# Altium schematic sheet [3] - MC60 MODULE.SchDoc
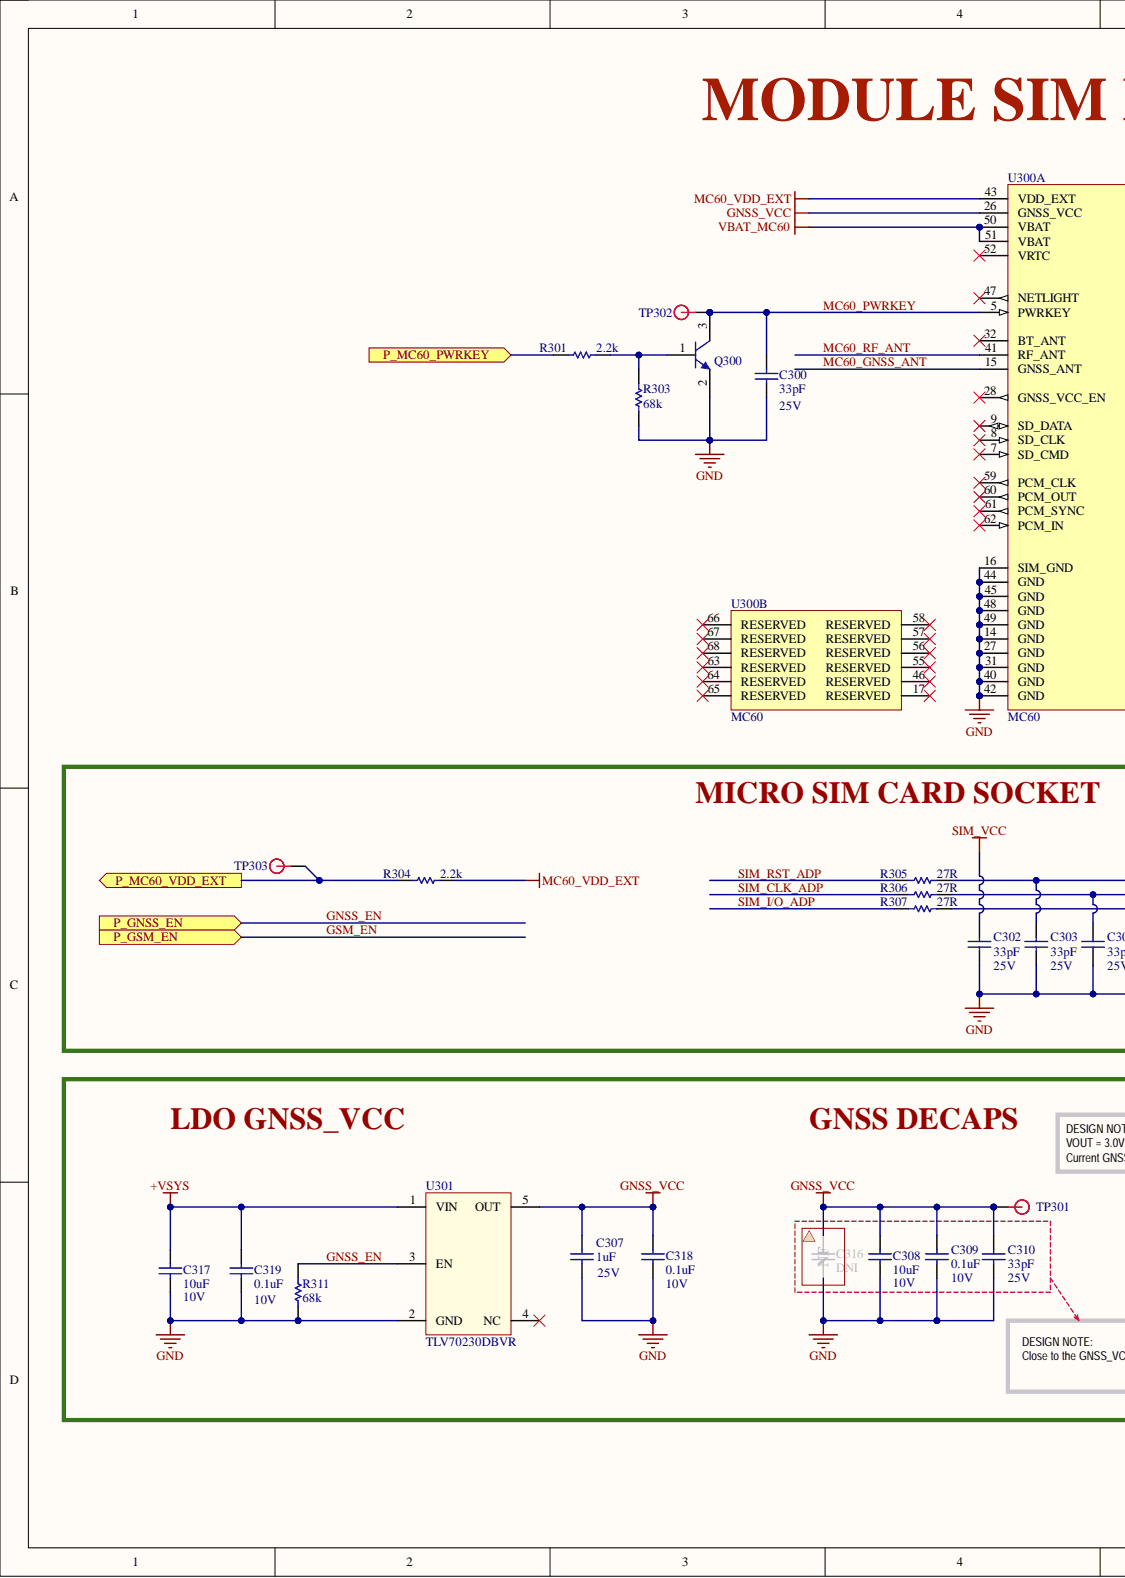
[diagram p0: [3] - MC60 MODULE.SchDoc, left]
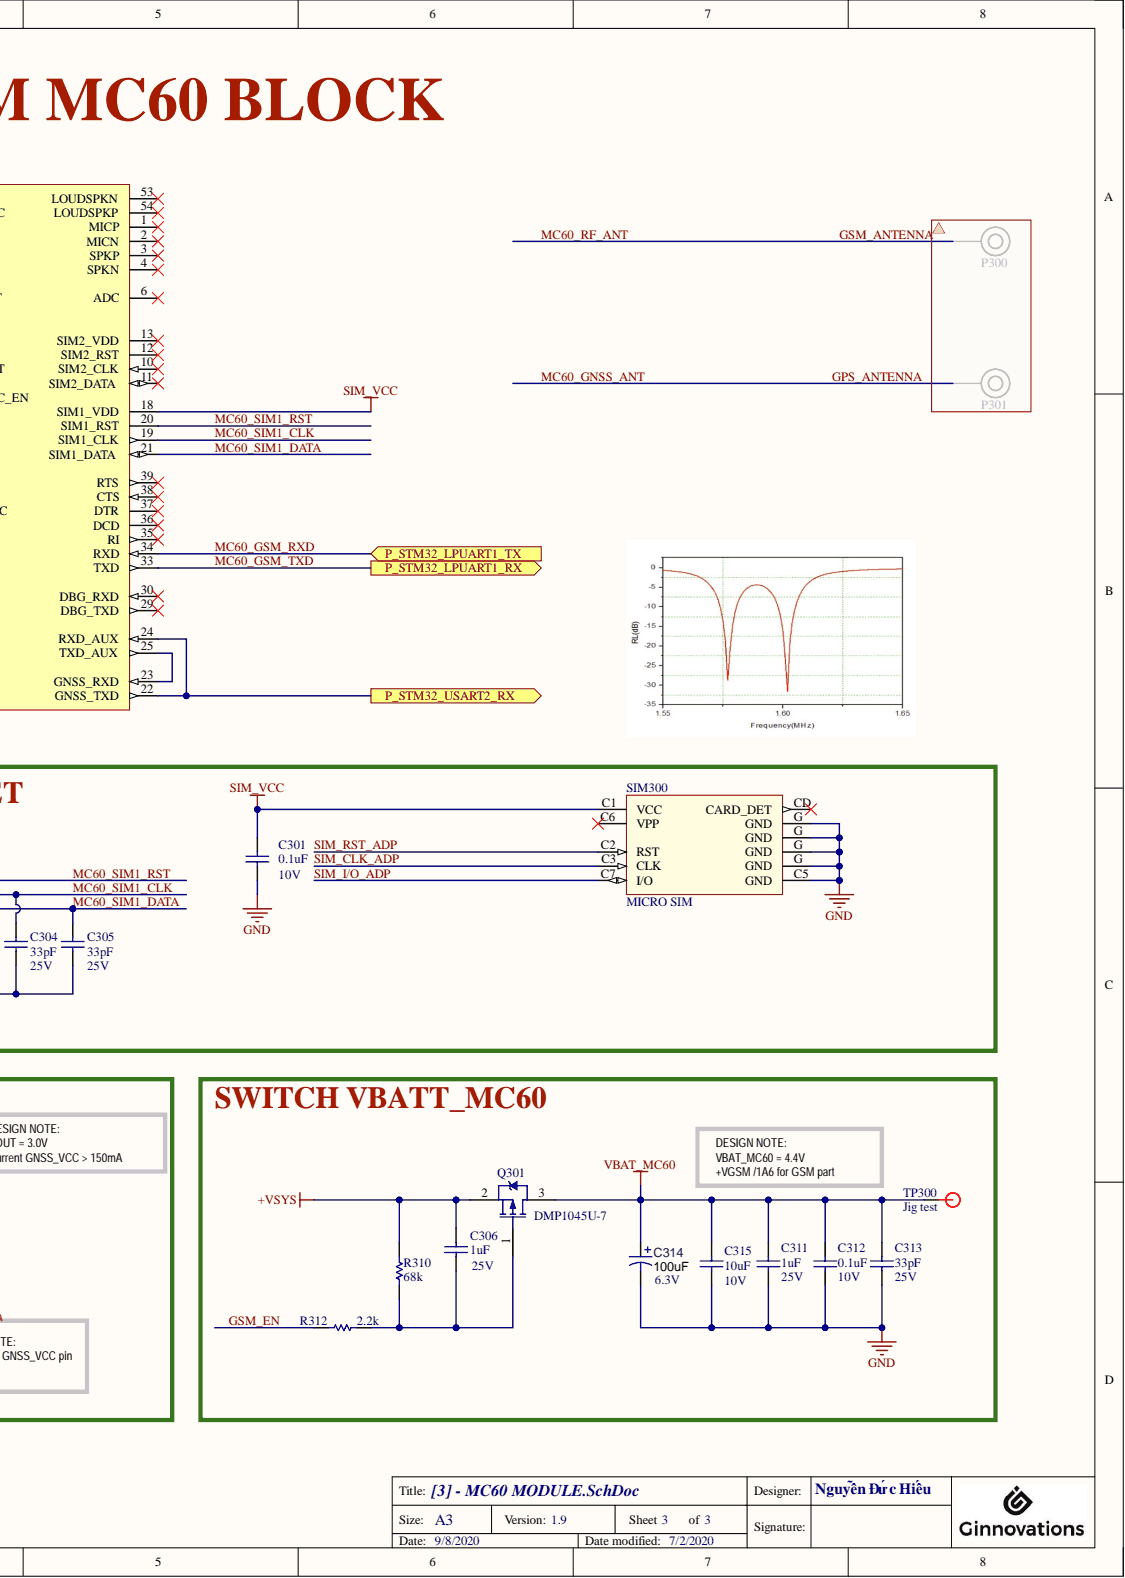
[diagram p1: [3] - MC60 MODULE.SchDoc, right]

## Parts
C300  33pF  Value2: 25V  [C0402L]
C301  0.1uF  Value2: 10V  [C0402L]
C302  33pF  Value2: 25V  [C0402L]
C303  33pF  Value2: 25V  [C0402L]
C304  33pF  Value2: 25V  [C0402L]
C305  33pF  Value2: 25V  [C0402L]
C306  1uF  Value2: 25V  [C0402L]
C307  1uF  Value2: 25V  [C0402L]
C308  10uF  Value2: 10V  [C0603Z]
C309  0.1uF  Value2: 10V  [C0402L]
C310  33pF  Value2: 25V  [C0402L]
C311  1uF  Value2: 25V  [C0402L]
C312  0.1uF  Value2: 10V  [C0402L]
C313  33pF  Value2: 25V  [C0402L]
C314  100uF  Value2: 6.3V  [6032-28]
C315  10uF  Value2: 10V  [C0603Z]
C317  10uF  Value2: 10V  [C0603Z]
C318  0.1uF  Value2: 10V  [C0402L]
C319  0.1uF  Value2: 10V  [C0402L]
Q300  MMBT3904  [SOT-23L]  (value not drawn)
Q301  DMP1045U-7  [SOT-23L]
R301  2.2k  [R0402L]
R303  68k  [R0402L]
R304  2.2k  [R0402L]
R305  27R  [R0402L]
R306  27R  [R0402L]
R307  27R  [R0402L]
R310  68k  [R0402L]
R311  68k  [R0402L]
R312  2.2k  [R0402L]
SIM300  MICRO SIM  [SI62C-01200]
TP300  Jig test  [TESTPOINT 1.2mm]  (no BOM)
TP301  Jig test  [TESTPOINT 1.2mm]  (value not drawn)  (no BOM)
TP302  2.54  [TESTPOINT 1.2mm]  (value not drawn)  (no BOM)
TP303  2.54  [TESTPOINT 1.2mm]  (value not drawn)  (no BOM)
U300  MC60  [MC60]  (parts A,B)
U301  TLV70230DBVR  [SOT23-5Z]

## Ports
P_GNSS_EN, P_GSM_EN, P_MC60_PWRKEY, P_MC60_VDD_EXT, P_STM32_LPUART1_RX, P_STM32_LPUART1_TX, P_STM32_USART2_RX

## Nets
+VSYS: C306.2 C317.1 C319.1 Q301.2 R310.1 U301.1(VIN)
GND: C300.2 C301.2 C302.2 C303.2 C304.2 C305.2 C307.1 C308.2 C309.2 C310.2 C311.2 C312.2 C313.2 C314.2 C315.2 C317.2 C318.2 C319.2 Q300.2 R303.1 R311.2 SIM300.C5(GND) SIM300.G(GND) U300.14(GND) U300.16(SIM_GND) U300.27(GND) U300.31(GND) U300.40(GND) U300.42(GND) U300.44(GND) U300.45(GND) U300.48(GND) U300.49(GND) U301.2(GND)
GNSS_VCC: C307.2 C308.1 C309.1 C310.1 C318.1 TP301.1 U300.26(GNSS_VCC) U301.5(OUT)
MC60_VDD_EXT: R304.1 U300.43(VDD_EXT)
SIM_VCC: C301.1 C302.1 SIM300.C1(VCC) U300.18(SIM1_VDD)
VBAT_MC60: C311.1 C312.1 C313.1 C314.1 C315.1 Q301.3 TP300.1 U300.50(VBAT) U300.51(VBAT)
GNSS_EN: R311.1 U301.3(EN) ; port P_GNSS_EN
GPS_ANTENNA: U300.15(GNSS_ANT)
GSM_ANTENNA: U300.41(RF_ANT)
GSM_EN: R312.1 ; port P_GSM_EN
MC60_GSM_RXD: U300.34(RXD) ; port P_STM32_LPUART1_TX
MC60_GSM_TXD: U300.33(TXD) ; port P_STM32_LPUART1_RX
MC60_PWRKEY: C300.1 Q300.3 TP302.1 U300.5(PWRKEY)
MC60_SIM1_CLK: C304.1 R306.2 U300.19(SIM1_CLK)
MC60_SIM1_DATA: C305.1 R307.2 U300.21(SIM1_DATA)
MC60_SIM1_RST: C303.1 R305.2 U300.20(SIM1_RST)
P_MC60_PWRKEY: R301.2 ; port P_MC60_PWRKEY
P_MC60_VDD_EXT: R304.2 TP303.1 ; port P_MC60_VDD_EXT
P_STM32_USART2_RX: U300.22(GNSS_TXD) U300.24(RXD_AUX) ; port P_STM32_USART2_RX
SIM_CLK_ADP: R306.1 SIM300.C3(CLK)
SIM_I/O_ADP: R307.1 SIM300.C7(I/O)
SIM_RST_ADP: R305.1 SIM300.C2(RST)
NetC306_1: C306.1 Q301.1 R310.2 R312.2
NetQ300_1: Q300.1 R301.1 R303.2
NetU300_23: U300.23(GNSS_RXD) U300.25(TXD_AUX)

Not connected: SIM300 C6(VPP) CD(CARD_DET); U300 1(MICP) 2(MICN) 3(SPKP) 4(SPKN) 6(ADC) 7(SD_CMD) 8(SD_CLK) 9(SD_DATA) 10(SIM2_CLK) 11(SIM2_DATA) 12(SIM2_RST) 13(SIM2_VDD) 17(RESERVED) 28(GNSS_VCC_EN) 29(DBG_TXD) 30(DBG_RXD) 32(BT_ANT) 35(RI) 36(DCD) 37(DTR) 38(CTS) 39(RTS) 46(RESERVED) 47(NETLIGHT) 52(VRTC) 53(LOUDSPKN) 54(LOUDSPKP) 55(RESERVED) 56(RESERVED) 57(RESERVED) 58(RESERVED) 59(PCM_CLK) 60(PCM_OUT) 61(PCM_SYNC) 62(PCM_IN) 63(RESERVED) 64(RESERVED) 65(RESERVED) 66(RESERVED) 67(RESERVED) 68(RESERVED); U301 4(NC)

## Library data of the parts
C300 C302-C305 C310 C313 = C0402: CAP CER 0402; Manufacturer: Murata Electronics; Manufacturer Part Number: GRM1555C1E330JA01D; Code HW: EAPCA00028; Comment Description: CAP CER 33PF 25V C0G/NP0 0402; Tolerance: 20%; Types: Capacitor; Case / Package: 0402; Component Kind: Standard; Ginno Items Number Stock: N/A; Ginno Part Number: N/A; Mounting Type: Surface Mount; Price: N/A; Value: 33pF
  C300 C302-C305: Library Name: Ginno.SCHLIB
  C310 C313: Library Name: Xphone Rev2.4.SCHLIB
C301 C309 C312 C318 C319 = C0402: CAP CER 0402; Manufacturer: Murata Electronics; Manufacturer Part Number: GRM155R71A104KA01D; Code HW: EAPCA00006; Comment Description: Multilayer Ceramic Capacitors MLCC - SMD/SMT 0402 0.1uF 10volts X7R 10%; Tolerance: 10%; Types: Capacitor; Case / Package: 0402; Component Kind: Standard; Ginno Items Number Stock: N/A; Ginno Part Number: N/A; Mounting Type: Surface Mount; Price: N/A; Value: 0.1uF
  C301: Library Name: Ginno Passive.SchLib
  C309 C312 C318 C319: Library Name: Xphone Rev2.4.SCHLIB
C306 C307 C311 = C0402: CAP CER 0402; Manufacturer: TDK Corporation; Manufacturer Part Number: C1005X5R1E105M050BC; Code HW: EAPCA00021; Comment Description: Multilayer Ceramic Capacitors MLCC - SMD/SMT 0402 25V 1uF X5R 20% T: 0.5mm; Tolerance: 20%; Types: Capacitor; Case / Package: 0402; Component Kind: Standard; Ginno Items Number Stock: N/A; Ginno Part Number: N/A; Mounting Type: Surface Mount; Price: N/A; Value: 1uF
  C306 C307: Library Name: Ginno Passive.SchLib
  C311: Library Name: Xphone Rev2.4.SCHLIB
C308 C315 C317 = C0603: CAP CER 0603; Manufacturer: Taiyo Yuden; Manufacturer Part Number: LMK107BBJ106MALT; Code HW: EAPCA00025; Comment Description: CAP CER 10UF 10V X5R 0603; Tolerance: 20%; Types: Capacitor; Case / Package: 0603; Component Kind: Standard; Ginno Items Number Stock: N/A; Ginno Part Number: N/A; Mounting Type: Surface Mount; Price: N/A; Value: 10uF
  C308 C317: Library Name: Xphone Rev2.4.SCHLIB
  C315: Library Name: Ginno.SCHLIB
C314 = CAP POL: CAP TANT 100UF 10% 10V 6032; Manufacturer: AVX Corporation; Manufacturer Part Number: TAJC107M006RNJ; Code HW: EAPCA00029; Comment Description: CAP TANT 100UF 20% 6.3V 2312; Tolerance: 20%; Types: Capacitor; Case / Package: 6032; Component Kind: Standard; Ginno Items Number Stock: N/A; Ginno Part Number: N/A; Mounting Type: Surface Mount; Price: N/A; Signal Integrity: Cap; Simulation: Cap; Value: 100uF; Library Name: Ginno.SCHLIB
Q300 = MMBT3904: Bipolar Transistors - BJT BIPOLAR TRANS NPN; Manufacturer: Diodes Incorporated; Manufacturer Part Number: MMBT3904-7-F; Code HW: EAPTR00001; Comment Description: TRANS NPN 40V 0.2A SMD SOT23-3; Value: MMBT3904-7-F; Case / Package: N/A; Component Kind: Standard; Ginno Items Number Stock: N/A; Ginno Part Number: N/A; Mounting Type: Surface Mount; Price: N/A; Tolerance: N/A; Types: Transistor; Value2: N/A; Library Name: Ginno.SCHLIB
Q301 = SIA449DJ: MOSFET P-CH 12V 4A SOT23; Manufacturer: Diodes Incorporated; Code HW: EAPTR00002; Types: Transistor; Case / Package: SOT-23; Comment Description: MOSFET P-CH 12V 4A SOT23; Component Kind: Standard; Ginno Items Number Stock: N/A; Ginno Part Number: N/A; Manufacturer Part Number: DMP1045U-7; Mounting Type: Surface Mount; Price: N/A; Tolerance: N/A; Value: DMP1045U-7; Value2: N/A; Library Name: GTRACKER REV1.5.SCHLIB
R301 R304 = R0402: RES SMD 0402; Manufacturer: Panasonic; Manufacturer Part Number: ERJ-2GEJ222X; Code HW: EAPRE00012; Comment Description: Thick Film Resistors - SMD 1/16watt 2.2Kohms 5%; Ginno Items Number Stock: NA; Tolerance: 5%; Types: Resistor; Value2: 1/10W; Case / Package: 0402; Component Kind: Standard; Ginno Part Number: N/A; Library Name: Ginno.SCHLIB; Mounting Type: Surface Mount; Price: N/A; Value: 2.2k
R303 R310 R311 = R0402: RES SMD 0402; Manufacturer: Panasonic; Manufacturer Part Number: ERJ-2GEJ683X; Code HW: EAPRE00006; Comment Description: RES SMD 68K OHM 5% 1/10W 0402; Ginno Items Number Stock: NA; Tolerance: 5%; Types: Resistor; Value2: 1/10W; Case / Package: 0402; Component Kind: Standard; Ginno Part Number: N/A; Library Name: Ginno.SCHLIB; Mounting Type: Surface Mount; Price: N/A; Value: 68k
R305-R307 = R0402: RES SMD 0402; Manufacturer: Panasonic; Manufacturer Part Number: ERJ-2GEJ270X; Code HW: EAPRE00005; Comment Description: Thick Film Resistors - SMD 0402 27ohms 5% AEC-Q200; Tolerance: 5%; Types: Resistor; Case / Package: 0402; Component Kind: Standard; Ginno Items Number Stock: N/A; Ginno Part Number: N/A; Mounting Type: Surface Mount; Price: N/A; Value: 27R; Value2: N/A; Library Name: Xphone Rev2.4.SCHLIB
R312 = R0402: RES SMD 0402; Manufacturer: Panasonic; Manufacturer Part Number: ERJ-2GEJ222X; Code HW: EAPRE00012; Comment Description: Thick Film Resistors - SMD 1/16watt 2.2Kohms 5%; Tolerance: 5%; Types: Resistor; Value2: 1/10W; Case / Package: 0402; Component Kind: Standard; Ginno Items Number Stock: N/A; Ginno Part Number: N/A; Mounting Type: Surface Mount; Price: N/A; Value: 2.2k; Library Name: Ginno.SCHLIB
SIM300 = SI62C-01200: MICRO SIM CARD SOCKET; Manufacturer: China; Manufacturer Part Number: XNT-0121AAAA07A; Code HW: EAPCN00015; Types: Connector; Value: XNT-0121AAAA07A; Case / Package: N/A; Comment Description: Simcard; Component Kind: Standard; Ginno Items Number Stock: N/A; Ginno Part Number: N/A; Mounting Type: Surface Mount; Price: N/A; Tolerance: N/A; Value2: N/A; Library Name: Gtracker Rev 1.8.SCHLIB
TP300-TP303 = TESTPOINT 5000: TEST POINT PC MINI .040"D RED; Types: TP; Ginno Items Number Stock: N/A; Ginno Part Number: N/A; Library Name: mLib.SchLib; Manufacturer: N/A; Manufacturer Part Number: N/A; Mounting Type: Surface Mount; Price: N/A; Tolerance: N/A; Value: N/A; Value2: N/A
  TP300 TP301: Case / Package: N/A
U300 = MC60: Manufacturer: Quectel; Manufacturer Part Number: MC60CA-04-STD; Code HW: EAPIC00026; Comment Description: Quad-band GSM/GPRS/GNSS Module LCC; Ginno Items Number Stock: NA; Types: IC; Case / Package: N/A; Component Kind: Standard; Ginno Part Number: N/A; Mounting Type: Surface Mount; Price: N/A; Tolerance: N/A; Value: MC60; Value2: N/A; Library Name: Gtracker.SCHLIB
U301 = TLV70233DBVR: IC REG LDO 3.0V 0.3A SOT23-5; Manufacturer: Texas Instruments; Code HW: EAPIC00023; Comment Description: IC REG LINEAR 3V 300MA SOT23-5; Value2: 300mA; Case / Package: SOT-23-5; Component Kind: Standard; Ginno Items Number Stock: N/A; Ginno Part Number: N/A; Manufacturer Part Number: TLV70230DBVR; Mounting Type: Surface Mount; Price: N/A; Tolerance: N/A; Types: IC; Value: TLV70230DBVR; Library Name: GTRACKER REV1.1 COPY.SCHLIB
